# Altium schematic sheet 08_FPGA.SchDoc(08_FPGA)
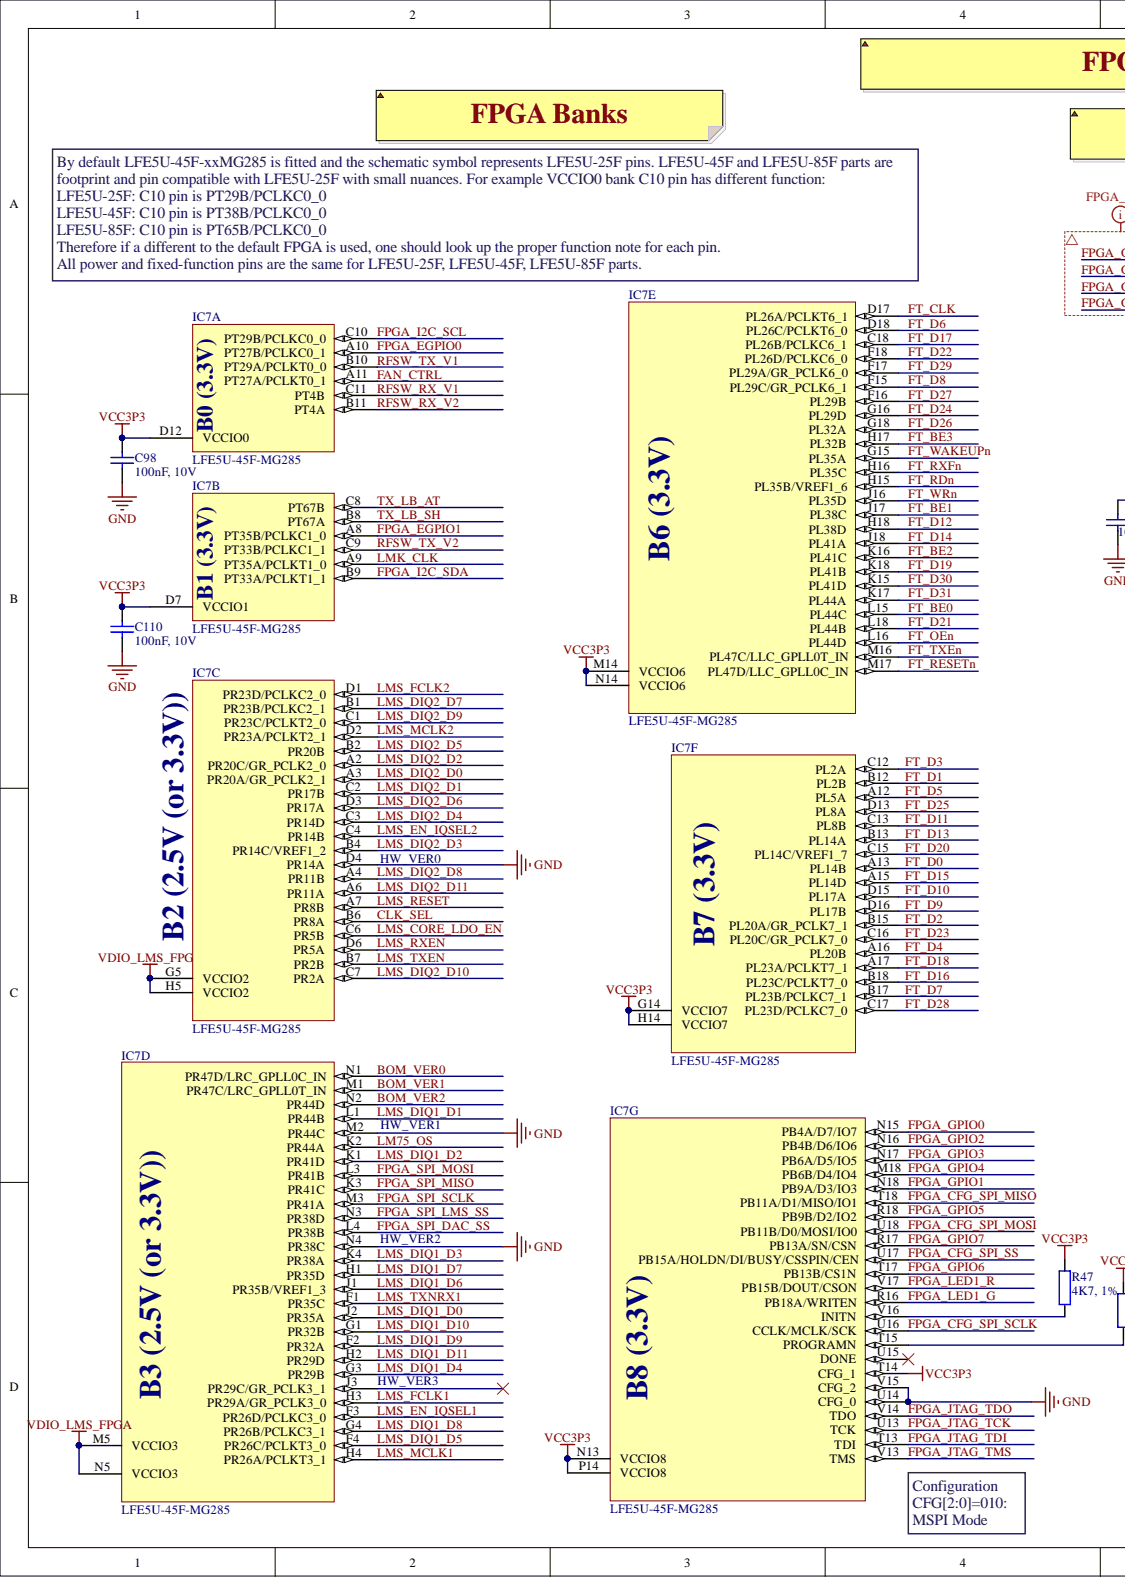
[diagram p0: 08_FPGA.SchDoc, left]
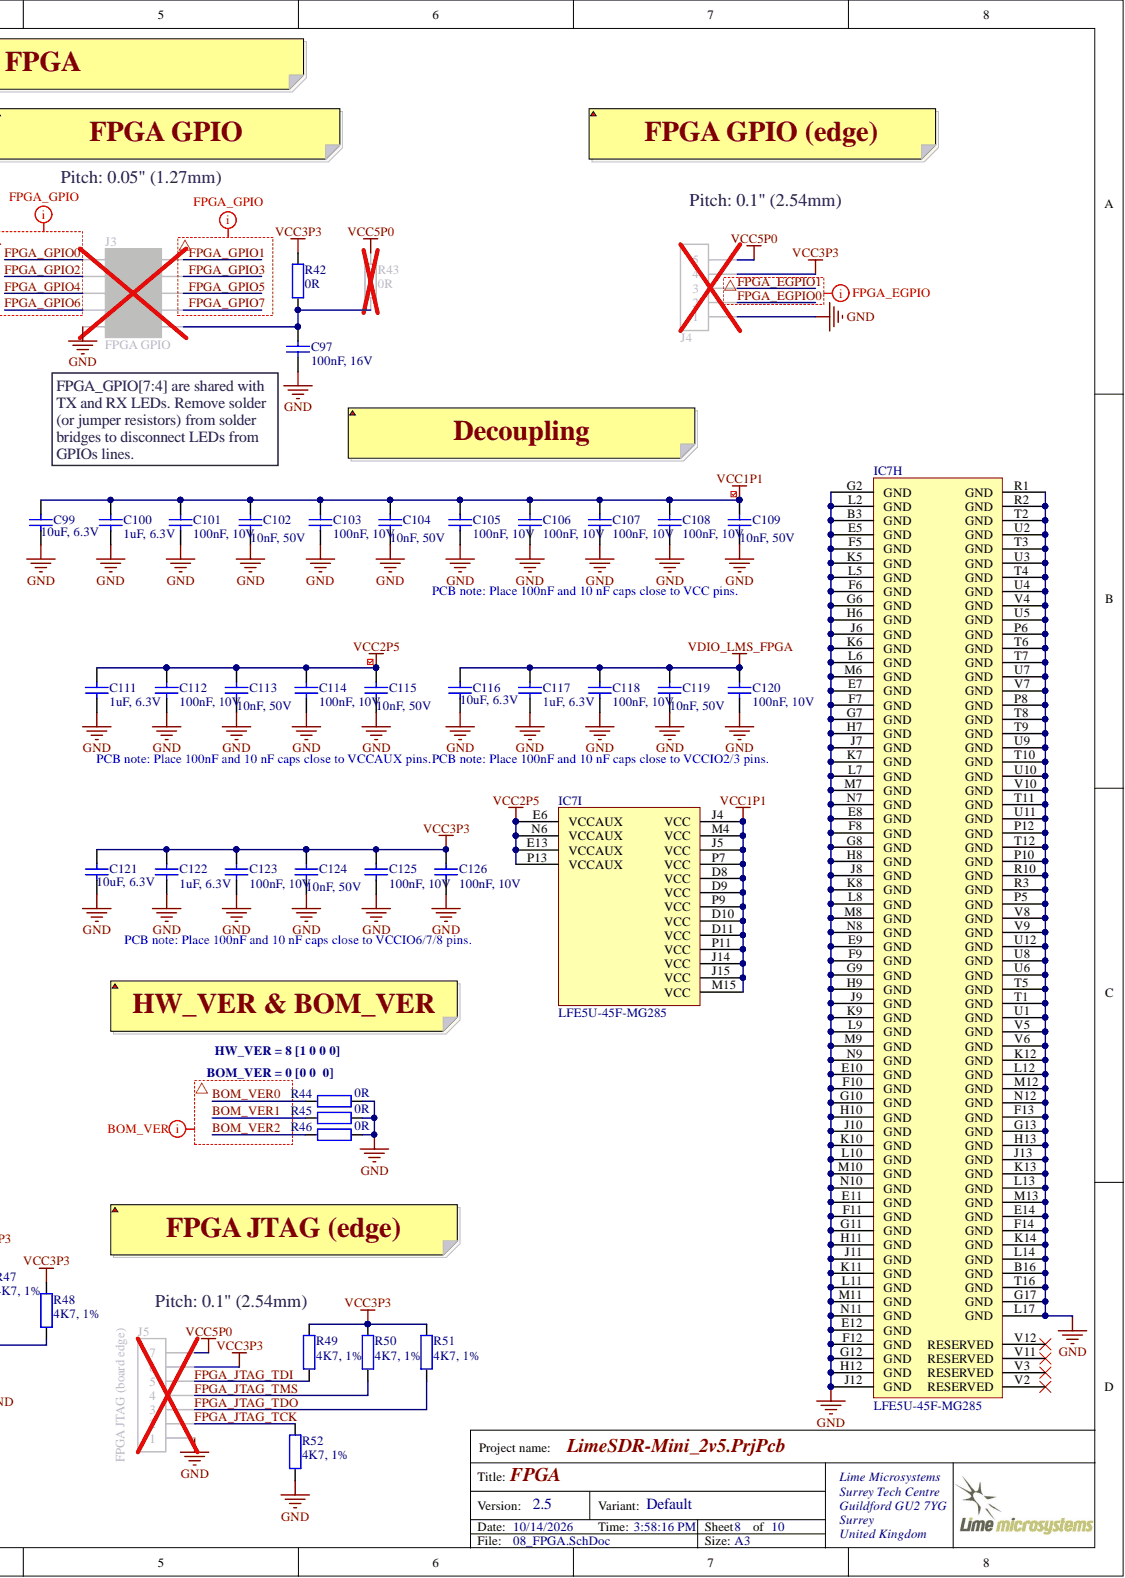
[diagram p1: 08_FPGA.SchDoc, right]

## Parts
C97  100nF, 16V  [CAP0402]
C98  100nF, 10V  [CAP0201]
C99  10uF, 6.3V  Voltage - Rated: 6.3V  [CAP0603]
C100  1uF, 6.3V  Voltage - Rated: 6.3V  [CAP0201]
C101  100nF, 10V  [CAP0201]
C102  10nF, 50V  [CAP0402]
C103  100nF, 10V  [CAP0201]
C104  10nF, 50V  [CAP0402]
C105  100nF, 10V  [CAP0201]
C106  100nF, 10V  [CAP0201]
C107  100nF, 10V  [CAP0201]
C108  100nF, 10V  [CAP0201]
C109  10nF, 50V  Voltage Rating (DC): 50V  [CAP0402]
C110  100nF, 10V  [CAP0201]
C111  1uF, 6.3V  [CAP0201]
C112  100nF, 10V  [CAP0201]
C113  10nF, 50V  [CAP0402]
C114  100nF, 10V  [CAP0201]
C115  10nF, 50V  Voltage Rating (DC): 50V  [CAP0402]
C116  10uF, 6.3V  Voltage - Rated: 6.3V  [CAP0603]
C117  1uF, 6.3V  Voltage - Rated: 6.3V  [CAP0201]
C118  100nF, 10V  [CAP0201]
C119  10nF, 50V  [CAP0402]
C120  100nF, 10V  [CAP0201]
C121  10uF, 6.3V  Voltage - Rated: 6.3V  [CAP0603]
C122  1uF, 6.3V  Voltage - Rated: 6.3V  [CAP0201]
C123  100nF, 10V  [CAP0201]
C124  10nF, 50V  [CAP0402]
C125  100nF, 10V  [CAP0201]
C126  100nF, 10V  [CAP0201]
IC7  LFE5U-45F-MG285  [LFE5U-25F-8MG285C_LATTICE_FPGA]  (parts A,B,C,D,E,F,G,H,I)
J3  FPGA GPIO  [SWD_10_pin]
J4  FPGA EGPIO (board edge)  [Header5x1_TH_v2]  (value not drawn)
J5  FPGA JTAG (board edge)  [Header7x1_TH_v2]
R42  0R  [RES0402]
R43  0R  [RES0402]
R44  0R  [RES0402]
R45  0R  [RES0402]
R46  0R  [RES0402]
R47  4K7, 1%  [RES0402]
R48  4K7, 1%  [RES0402]
R49  4K7, 1%  [RES0402]
R50  4K7, 1%  [RES0402]
R51  4K7, 1%  [RES0402]
R52  4K7, 1%  [RES0402]

## Nets
GND: C97.1 C98.2 C99.1 C100.1 C101.2 C102.1 C103.2 C104.1 C105.2 C106.2 C107.2 C108.2 C109.1 C110.2 C111.1 C112.2 C113.1 C114.2 C115.1 C116.1 C117.1 C118.2 C119.1 C120.2 C121.1 C122.2 C123.2 C124.1 C125.2 C126.2 IC7.B3(GND) IC7.B16(GND) IC7.D4(PR14A) IC7.E5(GND) IC7.E7(GND) IC7.E8(GND) IC7.E9(GND) IC7.E10(GND) IC7.E11(GND) IC7.E12(GND) IC7.E14(GND) IC7.F5(GND) IC7.F6(GND) IC7.F7(GND) IC7.F8(GND) IC7.F9(GND) IC7.F10(GND) IC7.F11(GND) IC7.F12(GND) IC7.F13(GND) IC7.F14(GND) IC7.G2(GND) IC7.G6(GND) IC7.G7(GND) IC7.G8(GND) IC7.G9(GND) IC7.G10(GND) IC7.G11(GND) IC7.G12(GND) IC7.G13(GND) IC7.G17(GND) IC7.H6(GND) IC7.H7(GND) IC7.H8(GND) IC7.H9(GND) IC7.H10(GND) IC7.H11(GND) IC7.H12(GND) IC7.H13(GND) IC7.J6(GND) IC7.J7(GND) IC7.J8(GND) IC7.J9(GND) IC7.J10(GND) IC7.J11(GND) IC7.J12(GND) IC7.J13(GND) IC7.K5(GND) IC7.K6(GND) IC7.K7(GND) IC7.K8(GND) IC7.K9(GND) IC7.K10(GND) IC7.K11(GND) IC7.K12(GND) IC7.K13(GND) IC7.K14(GND) IC7.L2(GND) IC7.L5(GND) IC7.L6(GND) IC7.L7(GND) IC7.L8(GND) IC7.L9(GND) IC7.L10(GND) IC7.L11(GND) IC7.L12(GND) IC7.L13(GND) IC7.L14(GND) IC7.L17(GND) IC7.M2(PR44C) IC7.M6(GND) IC7.M7(GND) IC7.M8(GND) IC7.M9(GND) IC7.M10(GND) IC7.M11(GND) IC7.M12(GND) IC7.M13(GND) IC7.N4(PR38C) IC7.N7(GND) IC7.N8(GND) IC7.N9(GND) IC7.N10(GND) IC7.N11(GND) IC7.N12(GND) IC7.P5(GND) IC7.P6(GND) IC7.P8(GND) IC7.P10(GND) IC7.P12(GND) IC7.R1(GND) IC7.R2(GND) IC7.R3(GND) IC7.R10(GND) IC7.T1(GND) IC7.T2(GND) IC7.T3(GND) IC7.T4(GND) IC7.T5(GND) IC7.T6(GND) IC7.T7(GND) IC7.T8(GND) IC7.T9(GND) IC7.T10(GND) IC7.T11(GND) IC7.T12(GND) IC7.T16(GND) IC7.U1(GND) IC7.U2(GND) IC7.U3(GND) IC7.U4(GND) IC7.U5(GND) IC7.U6(GND) IC7.U7(GND) IC7.U8(GND) IC7.U9(GND) IC7.U10(GND) IC7.U11(GND) IC7.U12(GND) IC7.U14(CFG_0) IC7.V4(GND) IC7.V5(GND) IC7.V6(GND) IC7.V7(GND) IC7.V8(GND) IC7.V9(GND) IC7.V10(GND) IC7.V15(CFG_2) J3.9 J4.1 J5.1 R44.1 R45.1 R46.1 R52.2
VCC1P1: C99.2 C100.2 C101.1 C102.2 C103.1 C104.2 C105.1 C106.1 C107.1 C108.1 C109.2 IC7.D8(VCC) IC7.D9(VCC) IC7.D10(VCC) IC7.D11(VCC) IC7.J4(VCC) IC7.J5(VCC) IC7.J14(VCC) IC7.J15(VCC) IC7.M4(VCC) IC7.M15(VCC) IC7.P7(VCC) IC7.P9(VCC) IC7.P11(VCC)
VCC2P5: C111.2 C112.1 C113.2 C114.1 C115.2 IC7.E6(VCCAUX) IC7.E13(VCCAUX) IC7.N6(VCCAUX) IC7.P13(VCCAUX)
VCC3P3: C98.1 C110.1 C121.2 C122.1 C123.1 C124.2 C125.1 C126.1 IC7.D7(VCCIO1) IC7.D12(VCCIO0) IC7.G14(VCCIO7) IC7.H14(VCCIO7) IC7.M14(VCCIO6) IC7.N13(VCCIO8) IC7.N14(VCCIO6) IC7.P14(VCCIO8) IC7.T14(CFG_1) J4.4 J5.6 R42.1 R47.1 R48.1 R49.1 R50.1 R51.1
VCC5P0: J4.5 J5.7 R43.1
VDIO_LMS_FPGA: C116.2 C117.2 C118.1 C119.2 C120.1 IC7.G5(VCCIO2) IC7.H5(VCCIO2) IC7.M5(VCCIO3) IC7.N5(VCCIO3)
BOM_VER0: IC7.N1(PR47D/LRC_GPLL0C_IN) R44.2
BOM_VER1: IC7.M1(PR47C/LRC_GPLL0T_IN) R45.2
BOM_VER2: IC7.N2(PR44D) R46.2
CLK_SEL: IC7.B6(PR8A)
FAN_CTRL: IC7.A11(PT27A/PCLKT0_1)
FPGA_CFG_SPI_MISO: IC7.T18(PB11A/D1/MISO/IO1)
FPGA_CFG_SPI_MOSI: IC7.U18(PB11B/D0/MOSI/IO0)
FPGA_CFG_SPI_SCLK: IC7.U16(CCLK/MCLK/SCK)
FPGA_CFG_SPI_SS: IC7.U17(PB15A/HOLDN/DI/BUSY/CSSPIN/CEN)
FPGA_EGPIO0: IC7.A10(PT27B/PCLKC0_1) J4.2
FPGA_EGPIO1: IC7.A8(PT35B/PCLKC1_0) J4.3
FPGA_GPIO0: IC7.N15(PB4A/D7/IO7) J3.1
FPGA_GPIO1: IC7.N18(PB9A/D3/IO3) J3.2
FPGA_GPIO2: IC7.N16(PB4B/D6/IO6) J3.3
FPGA_GPIO3: IC7.N17(PB6A/D5/IO5) J3.4
FPGA_GPIO4: IC7.M18(PB6B/D4/IO4) J3.5
FPGA_GPIO5: IC7.R18(PB9B/D2/IO2) J3.6
FPGA_GPIO6: IC7.T17(PB13B/CS1N) J3.7
FPGA_GPIO7: IC7.R17(PB13A/SN/CSN) J3.8
FPGA_I2C_SCL: IC7.C10(PT29B/PCLKC0_0)
FPGA_I2C_SDA: IC7.B9(PT33A/PCLKT1_1)
FPGA_JTAG_TCK: IC7.U13(TCK) J5.2 R52.1
FPGA_JTAG_TDI: IC7.T13(TDI) J5.5 R49.2
FPGA_JTAG_TDO: IC7.V14(TDO) J5.3 R51.2
FPGA_JTAG_TMS: IC7.V13(TMS) J5.4 R50.2
FPGA_LED1_G: IC7.R16(PB18A/WRITEN)
FPGA_LED1_R: IC7.V17(PB15B/DOUT/CSON)
FPGA_SPI_DAC_SS: IC7.L4(PR38B)
FPGA_SPI_LMS_SS: IC7.N3(PR38D)
FPGA_SPI_MISO: IC7.K3(PR41C)
FPGA_SPI_MOSI: IC7.L3(PR41B)
FPGA_SPI_SCLK: IC7.M3(PR41A)
FT_BE0: IC7.L15(PL44C)
FT_BE1: IC7.J17(PL38C)
FT_BE2: IC7.K16(PL41C)
FT_BE3: IC7.H17(PL32B)
FT_CLK: IC7.D17(PL26A/PCLKT6_1)
FT_D0: IC7.A13(PL14B)
FT_D1: IC7.B12(PL2B)
FT_D2: IC7.B15(PL20A/GR_PCLK7_1)
FT_D3: IC7.C12(PL2A)
FT_D4: IC7.A16(PL20B)
FT_D5: IC7.A12(PL5A)
FT_D6: IC7.D18(PL26C/PCLKT6_0)
FT_D7: IC7.B17(PL23B/PCLKC7_1)
FT_D8: IC7.F15(PL29C/GR_PCLK6_1)
FT_D9: IC7.D16(PL17B)
FT_D10: IC7.D15(PL17A)
FT_D11: IC7.C13(PL8B)
FT_D12: IC7.H18(PL38D)
FT_D13: IC7.B13(PL14A)
FT_D14: IC7.J18(PL41A)
FT_D15: IC7.A15(PL14D)
FT_D16: IC7.B18(PL23C/PCLKT7_0)
FT_D17: IC7.C18(PL26B/PCLKC6_1)
FT_D18: IC7.A17(PL23A/PCLKT7_1)
FT_D19: IC7.K18(PL41B)
FT_D20: IC7.C15(PL14C/VREF1_7)
FT_D21: IC7.L18(PL44B)
FT_D22: IC7.F18(PL26D/PCLKC6_0)
FT_D23: IC7.C16(PL20C/GR_PCLK7_0)
FT_D24: IC7.G16(PL29D)
FT_D25: IC7.D13(PL8A)
FT_D26: IC7.G18(PL32A)
FT_D27: IC7.F16(PL29B)
FT_D28: IC7.C17(PL23D/PCLKC7_0)
FT_D29: IC7.F17(PL29A/GR_PCLK6_0)
FT_D30: IC7.K15(PL41D)
FT_D31: IC7.K17(PL44A)
FT_OEn: IC7.L16(PL44D)
FT_RDn: IC7.H15(PL35B/VREF1_6)
FT_RESETn: IC7.M17(PL47D/LLC_GPLL0C_IN)
FT_RXFn: IC7.H16(PL35C)
FT_TXEn: IC7.M16(PL47C/LLC_GPLL0T_IN)
FT_WAKEUPn: IC7.G15(PL35A)
FT_WRn: IC7.J16(PL35D)
LM75_OS: IC7.K2(PR44A)
LMK_CLK: IC7.A9(PT35A/PCLKT1_0)
LMS_CORE_LDO_EN: IC7.C6(PR5B)
LMS_DIQ1_D0: IC7.J2(PR35A)
LMS_DIQ1_D1: IC7.L1(PR44B)
LMS_DIQ1_D2: IC7.K1(PR41D)
LMS_DIQ1_D3: IC7.K4(PR38A)
LMS_DIQ1_D4: IC7.G3(PR29B)
LMS_DIQ1_D5: IC7.F4(PR26C/PCLKT3_0)
LMS_DIQ1_D6: IC7.J1(PR35B/VREF1_3)
LMS_DIQ1_D7: IC7.H1(PR35D)
LMS_DIQ1_D8: IC7.G4(PR26B/PCLKC3_1)
LMS_DIQ1_D9: IC7.F2(PR32A)
LMS_DIQ1_D10: IC7.G1(PR32B)
LMS_DIQ1_D11: IC7.H2(PR29D)
LMS_DIQ2_D0: IC7.A3(PR20A/GR_PCLK2_1)
LMS_DIQ2_D1: IC7.C2(PR17B)
LMS_DIQ2_D2: IC7.A2(PR20C/GR_PCLK2_0)
LMS_DIQ2_D3: IC7.B4(PR14C/VREF1_2)
LMS_DIQ2_D4: IC7.C3(PR14D)
LMS_DIQ2_D5: IC7.B2(PR20B)
LMS_DIQ2_D6: IC7.D3(PR17A)
LMS_DIQ2_D7: IC7.B1(PR23B/PCLKC2_1)
LMS_DIQ2_D8: IC7.A4(PR11B)
LMS_DIQ2_D9: IC7.C1(PR23C/PCLKT2_0)
LMS_DIQ2_D10: IC7.C7(PR2A)
LMS_DIQ2_D11: IC7.A6(PR11A)
LMS_EN_IQSEL1: IC7.F3(PR26D/PCLKC3_0)
LMS_EN_IQSEL2: IC7.C4(PR14B)
LMS_FCLK1: IC7.H3(PR29A/GR_PCLK3_0)
LMS_FCLK2: IC7.D1(PR23D/PCLKC2_0)
LMS_MCLK1: IC7.H4(PR26A/PCLKT3_1)
LMS_MCLK2: IC7.D2(PR23A/PCLKT2_1)
LMS_RESET: IC7.A7(PR8B)
LMS_RXEN: IC7.D6(PR5A)
LMS_TXEN: IC7.B7(PR2B)
LMS_TXNRX1: IC7.F1(PR35C)
RFSW_RX_V1: IC7.C11(PT4B)
RFSW_RX_V2: IC7.B11(PT4A)
RFSW_TX_V1: IC7.B10(PT29A/PCLKT0_0)
RFSW_TX_V2: IC7.C9(PT33B/PCLKC1_1)
TX_LB_AT: IC7.C8(PT67B)
TX_LB_SH: IC7.B8(PT67A)
NetC97_2: C97.2 J3.10 R42.2 R43.2
NetIC7_T15: IC7.T15(PROGRAMN) R48.2
NetIC7_V16: IC7.V16(INITN) R47.2
N00332:
N00333:
N00334:
N00335:

Not connected: IC7 J3(PR29C/GR_PCLK3_1) U15(DONE) V2(RESERVED) V3(RESERVED) V11(RESERVED) V12(RESERVED)

## Library data of the parts
C97 = Cap_0402: Part Description: 0.10µF ±10% 16V X7R Ceramic Capacitor -55°C ~ 125°C Surface Mount, MLCC 0402 (1005 Metric) 0.039" L x 0.020" W (1.00mm x 0.50mm); Manufacturer Part Number 1: GRM155R71C104KA88D; Manufacturer: Murata Electronics; Type: General Purpose MLCC; Tolerance: 10 %; Temperature Coefficient / Code: +/- 15 %; Dielectric: X7R; Case Width: 0.5 mm; Case Length: 1 mm; Capacitance: 0.1 uF; Voltage Rating DC: 16 V; Value: 100nF, 16V; Termination Style: SMD/SMT; Supplier Part Number 1: 81-GRM155R71C104KA88; Supplier 1: Mouser; Standard Pack Qty: 10000; Series: GRM; RoHS - Mouser: Y; RoHS: RoHS Compliant; Reeling: True; Product Category: Low & Medium Capacitance SMD Ceramic Chip Capacitors; Product: General Type MLCCs; Packaging: Reel; Package / Case: 0402 (1005 metric); Operating Temperature Range: - 55 C to + 125 C; Minimum Order Quantity: 1; Minimum Operating Temperature: - 55 C; Maximum Operating Temperature: + 125 C; Manufacturer Part Number: GRM155R71C104KA88D; Component Kind: Standard; Category: Multilayer Ceramic Capacitors MLCC - SMD/SMT; Case Height: 0.5 mm; Case Code - mm: 1005; Case Code - in: 0402; Brand: Murata Electronics; Library Name: LimeMicroAltiumLib_schLib.SchLib
C98 C101 C103 C105-C108 C110 C112 C114 C118 C120 C123 C125 C126 = Cap_0402: Part Description: 0.1 µF ±10% 10V Ceramic Capacitor X6S 0201 (0603 Metric); Manufacturer Part Number 1: GRT033C81A104KE01D; Manufacturer: Murata; Voltage Rating DC: 10 VDC; Type: Voltage and Temperature Derating Recommended Product; Tolerance: 10 %; Product Status: New Product; Dielectric: X6S; Capacitance: 0.1 uF; Brand: Murata Electronics; Width: 0.3 mm; Value: 100nF, 10V; Termination Style: SMD/SMT; Supplier Part Number 1: 490-12232-1-ND; Supplier 1: Digi-Key; Series: GRM; RoHS - Mouser: Y; RoHS: RoHS Compliant; Reeling: True; Product: General Type MLCCs; Packaging: Reel; Package / Case: 0201 (0603 metric); Minimum Order Quantity: 1; Minimum Operating Temperature: - 55 C; Maximum Operating Temperature: + 125 C; Length: 0.6 mm; Height: 0.3 mm; Component Kind: Standard; Category: Multilayer Ceramic Capacitors MLCC - SMD/SMT; Case Code - mm: 0603; Case Code - in: 0201; Library Name: LimeMicroAltiumLib_schLib.SchLib
C99 C116 C121 = Cap_0603: Part Description: 10µF ±20% 6.3V X5R Ceramic Capacitor -55°C ~ 85°C Surface Mount, MLCC 0603 (1608 Metric) 0.063" L x 0.031" W (1.60mm x 0.80mm); Manufacturer Part Number 1: CC0603MRX5R5BB106; Manufacturer: Yageo; Tolerance: ±20%; Capacitance: 10µF; Value: 10uF, 6.3V; Supplier Part Number 1: 311-1448-2-ND; Supplier 1: Digi-Key; Package / Case: 0603 (1608 Metric); Component Kind: Standard; Category: Capacitors; Library Name: LimeFPGAboard_schLib.SchLib
C100 C111 C117 = Cap_0402: Part Description: 1µF ±20% 6.3V X5R Ceramic Capacitor -55°C ~ 85°C Surface Mount, MLCC 0201 (0603 Metric) 0.024" L x 0.012" W (0.60mm x 0.30mm); Manufacturer Part Number 1: JMK063ABJ105MP-F; Manufacturer: TDK Corporation; Tolerance: ±20%; Temperature Coefficient: X5R; Capacitance: 1.0µF; Value: 1uF, 6.3V; Thickness (Max): -; Supplier Part Number 1: 587-5864-2-ND; Supplier 1: Digi-Key; Size / Dimension: 0.024" L x 0.012" W (0.60mm x 0.30mm); RoHS: RoHS Compliant; Ratings: -; Packaging: Cut Tape (CT); Package / Case: 0201 (0603 Metric); Operating Temperature: -55°C ~ 85°C; Mounting Type: Surface Mount, MLCC; Lead Style: -; Lead Spacing: -; Height - Seated (Max): -; Features: -; Component Kind: Standard; Category: Capacitors; Applications: General Purpose; Library Name: LimeMicroAltiumLib_schLib.SchLib
  C111: Voltage - Rated: 6.3V
C102 C104 C109 C113 C115 C119 C124 = Cap_0402: Part Description: 10000pF ±10% 50V Ceramic Capacitor X7R 0402 (1005 Metric); Manufacturer Part Number 1: GRM155R71H103KA88D; Manufacturer: Murata; Voltage: 25V; Tolerance: 10%; Material: Ceramic; Dielectric: X7R; Width: 0.02inch; Voltage Rating: 50V; value: 10nF, 50V; Thickness: 0.022inch; Termination: SMD/SMT; Supplier Part Number 1: 490-4516-1-ND; Supplier 1: Digi-Key; Stock 1: 8941817; RoHS: Yes; REACH SVHC: No SVHC; Radiation Hardening: No; Pricing 1: 1=0,10000, 10=0,03800, 100=0,01690, 500=0,01208, 1000=0,00949, 2500=0,00862, 5000=0,00794 (USD); Packaging: Tape and Reel; Package Quantity: 1; Number of Pins: 2; Mount: Surface Mount; Min Operating Temperature: -55°C; Max Operating Temperature: 125°C; Length: 1mm; Lead Free: Lead Free; Height: 0.5mm; Depth: 0.5mm; Component Kind: Standard; Case Code (Metric): 1005; Case Code (Imperial): 0402; Case/Package: 0402; Capacitance: 10nF; Library Name: LimeFPGAboard_schLib.SchLib
  C102 C104 C113 C119 C124: Voltage Rating (DC): 50V
C122 = Cap_0402: Part Description: 1µF ±20% 6.3V X5R Ceramic Capacitor -55°C ~ 85°C Surface Mount, MLCC 0201 (0603 Metric) 0.024" L x 0.012" W (0.60mm x 0.30mm); Manufacturer Part Number 1: JMK063ABJ105MP-F; Manufacturer: TDK Corporation; Value: 1uF, 20%; Tolerance: ±20%; Temperature Coefficient: X5R; Capacitance: 1.0µF; Thickness (Max): -; Supplier Part Number 1: 587-5864-2-ND; Supplier 1: Digi-Key; Size / Dimension: 0.024" L x 0.012" W (0.60mm x 0.30mm); RoHS: RoHS Compliant; Ratings: -; Packaging: Cut Tape (CT); Package / Case: 0201 (0603 Metric); Operating Temperature: -55°C ~ 85°C; Mounting Type: Surface Mount, MLCC; Lead Style: -; Lead Spacing: -; Height - Seated (Max): -; Features: -; Component Kind: Standard; Category: Capacitors; Applications: General Purpose; Library Name: LimeMicroAltiumLib_schLib.SchLib
IC7 = LFE5U-25F-MG285: Part Description: series Field Programmable Gate Array (FPGA) IC 118 1990656 44000 285-LFBGA, CSPBGA; Manufacturer Part Number 1: LFE5U-45F-6MG285C; Manufacturer: Lattice Semiconductor; RAM Size: 1990656b; Number of Logic Elements/Cells: 44000; Number of Logic Blocks (LABs): 11000; Number of I/Os: 118; Supplier Part Number 1: LFE5U-45F-6MG285C-ND; Supplier 1: Digi-Key; Stock 1: 0; RoHS Compliant: No; RoHS: Yes; Pricing 1: 168=15,73000 (USD); Mount: Surface Mount; Min Operating Temperature: 0°C; Max Operating Temperature: 85°C; ComponentLink1URL: http://www.latticesemi.com/view_document?document_id=50461&src-supplier=Digi-Key; ComponentLink1Description: http://www.latticesemi.com/view_document?document_id=50461&src-supplier=Digi-Key; Component Kind: Standard; Case/Package: TFBGA; Library Name: LimeMicroAltiumLib_schLib_r03.SchLib
J3 = Header 5X2_SWD: Header, 5-Pin, Dual row; Part Description: CONN HDR 1.27MM SMD AU 10POS; Manufacturer Part Number 1: M50-3600542; Manufacturer: Harwin Inc; Row Spacing: 0.050" (1.27mm); Number of Positions Loaded: All; Number of Positions: 10; Molding Height Above Board: 0.039" (1.00mm); Connector Type: Unshrouded; Termination: Solder; Supplier Part Number 1: 952-1389-ND; Supplier 1: Digi-Key; RoHS: RoHS Compliant; Pitch: 0.050" (1.27mm); Packaging: Bulk; Number of Rows: 2; Mounting Type: Surface Mount; Height Stacking (Mating): -; Features: -; Contact Mating Length: 0.118" (3.00mm); Contact Finish Thickness: 3µin (0.08µm); Contact Finish: Gold; Component Kind: Standard; Color: Black; Category: Connectors, Interconnects; Library Name: LimeMicroAltiumLib_schLib.SchLib
J4 = Header5P: Header, 5-pin; Part Description: 5 Positions Header Connector 0.100" (2.54mm) Through Hole Tin; Manufacturer Part Number 1: 69190-405HLF; Manufacturer: Amphenol FCI; Style: Board to Board; Shrouding: Unshrouded; Pitch - Mating: 0.100" (2.54mm); Overall Contact Length: 0.450" (11.43mm); Number of Positions Loaded: All; Number of Positions: 5; Material Flammability Rating: UL94 V-0; Contact Length - Post: 0.120" (3.05mm); Contact Length - Mating: 0.230" (5.84mm); Voltage Rating: -; Termination: Press-Fit, Solder; Supplier Part Number 1: 609-4726-ND; Supplier 1: Digi-Key; Stock 1: 2326; Row Spacing - Mating: -; RoHS: RoHS Compliant; Pricing 1: 1=0.28, 10=0.26, 100=0.1799, 500=0.15224, 1000=0.12456, 5000=0.11072, 10000=0.10034 (USD); Part Status: Active; Packaging: Bulk; Operating Temperature: -; Number of Rows: 1; Mounting Type: Through Hole; Mated Stacking Heights: -; Insulation Material: Polycyclohexylenedimethylene Terephthalate (PCT), Glass Filled; Insulation Height: 0.100" (2.54mm); Insulation Color: Black; Ingress Protection: -; Features: -; Fastening Type: Push-Pull; Current Rating: -; Contact Type: Male Pin; Contact Shape: Square; Contact Material: Phosphor Bronze; Contact Finish Thickness - Post: -; Contact Finish Thickness - Mating: 100µin (2.54µm); Contact Finish - Post: Tin; Contact Finish - Mating: Tin; Connector Type: Header; ComponentLink1URL: http://portal.fciconnect.com/Comergent//fci/drawing/69190.pdf; ComponentLink1Description: http://portal.fciconnect.com/Comergent//fci/drawing/69190.pdf; Component Kind: Standard; Category: Connectors, Interconnects; Applications: -; Library Name: LimeMicroAltiumLib_schLib.SchLib
J5 = Header7P: Header, 7-pin; Part Description: 7 Positions Header, Unshrouded, Breakaway Connector 0.100" (2.54mm) Through Hole Tin; Manufacturer Part Number 1: PEC07SAAN; Manufacturer: Sullins Connector Solutions; Pitch: 0.100" (2.54mm); Number of Positions Loaded: All; Number of Positions: 7; Connector Type: Header, Unshrouded, Breakaway; Termination: Solder; Supplier Part Number 1: S1012E-07-ND; Supplier 1: Digi-Key; Row Spacing: -; RoHS: RoHS Compliant; Packaging: Bulk; Number of Rows: 1; Mounting Type: Through Hole; Features: -; Fastening Type: -; Contact Type: Male Pin; Contact Mating Length: 0.230" (5.84mm); Contact Finish Thickness: 100µin (2.54µm); Contact Finish: Tin; Component Kind: Standard; Color: Black; Category: Connectors, Interconnects; Library Name: LimeMicroAltiumLib_schLib.SchLib
R42-R46 = Res_0402: Part Description: RES SMD 0.0OHM JUMPER 1/16W 0402; Manufacturer Part Number 1: RC0402JR-070RL; Manufacturer: Yageo; Tolerance: Jumper; Resistance (Ohms): 0.0; Power (Watts): 0.063W, 1/16W; Value: 0R; Temperature Coefficient: -; Supplier Part Number 1: 311-0.0JRTR-ND; Supplier Device Package: 0402; Supplier 1: Digi-Key; Size / Dimension: 0.039" L x 0.020" W (1.00mm x 0.50mm); RoHS: RoHS Compliant; Packaging: Tape & Reel (TR); Package / Case: 0402 (1005 Metric); Operating Temperature: -55°C ~ 155°C; Number of Terminations: 2; Height: 0.016" (0.40mm); Features: Moisture Resistant; Composition: Thick Film; Component Kind: Standard; Category: Resistors; Library Name: LimeFPGAboard_schLib.SchLib
R47-R52 = Res_0402: Part Description: ±1% 0.063W, 1/16W Chip Resistor 0402 (1005 Metric) Moisture Resistant Thick Film; Manufacturer Part Number 1: RC0402FR-074K7L; Manufacturer: Yageo; Temperature Coefficient: ±100ppm/°C; Power (Watts): 0.063W, 1/16W; Value: 4K7, 1%; Tolerance: ±1%; Supplier Part Number 1: 311-4.7KLRCT-ND; Supplier Device Package: 0402; Supplier 1: Digi-Key; Stock 1: 4788231; Size / Dimension: 0.039" L x 0.020" W (1.00mm x 0.50mm); RoHS: RoHS Compliant; Pricing 1: 1=0.1, 10=0.014, 100=0.0056, 1000=0.00253, 2500=0.0022, 5000=0.00181 (USD); Part Status: Active; Packaging: Cut Tape (CT); Package / Case: 0402 (1005 Metric); Operating Temperature: -55°C ~ 155°C; Height - Seated (Max): 0.016" (0.40mm); Features: Moisture Resistant; Failure Rate: -; Composition: Thick Film; ComponentLink1URL: http://www.yageo.com/documents/recent/PYu-RC_Group_51_RoHS_L_7.pdf; ComponentLink1Description: http://www.yageo.com/documents/recent/PYu-RC_Group_51_RoHS_L_7.pdf; Component Kind: Standard; Category: Resistors; Library Name: LimeFPGAboard_schLib.SchLib
